# Altium schematic sheet Power Conditioning.SchDoc
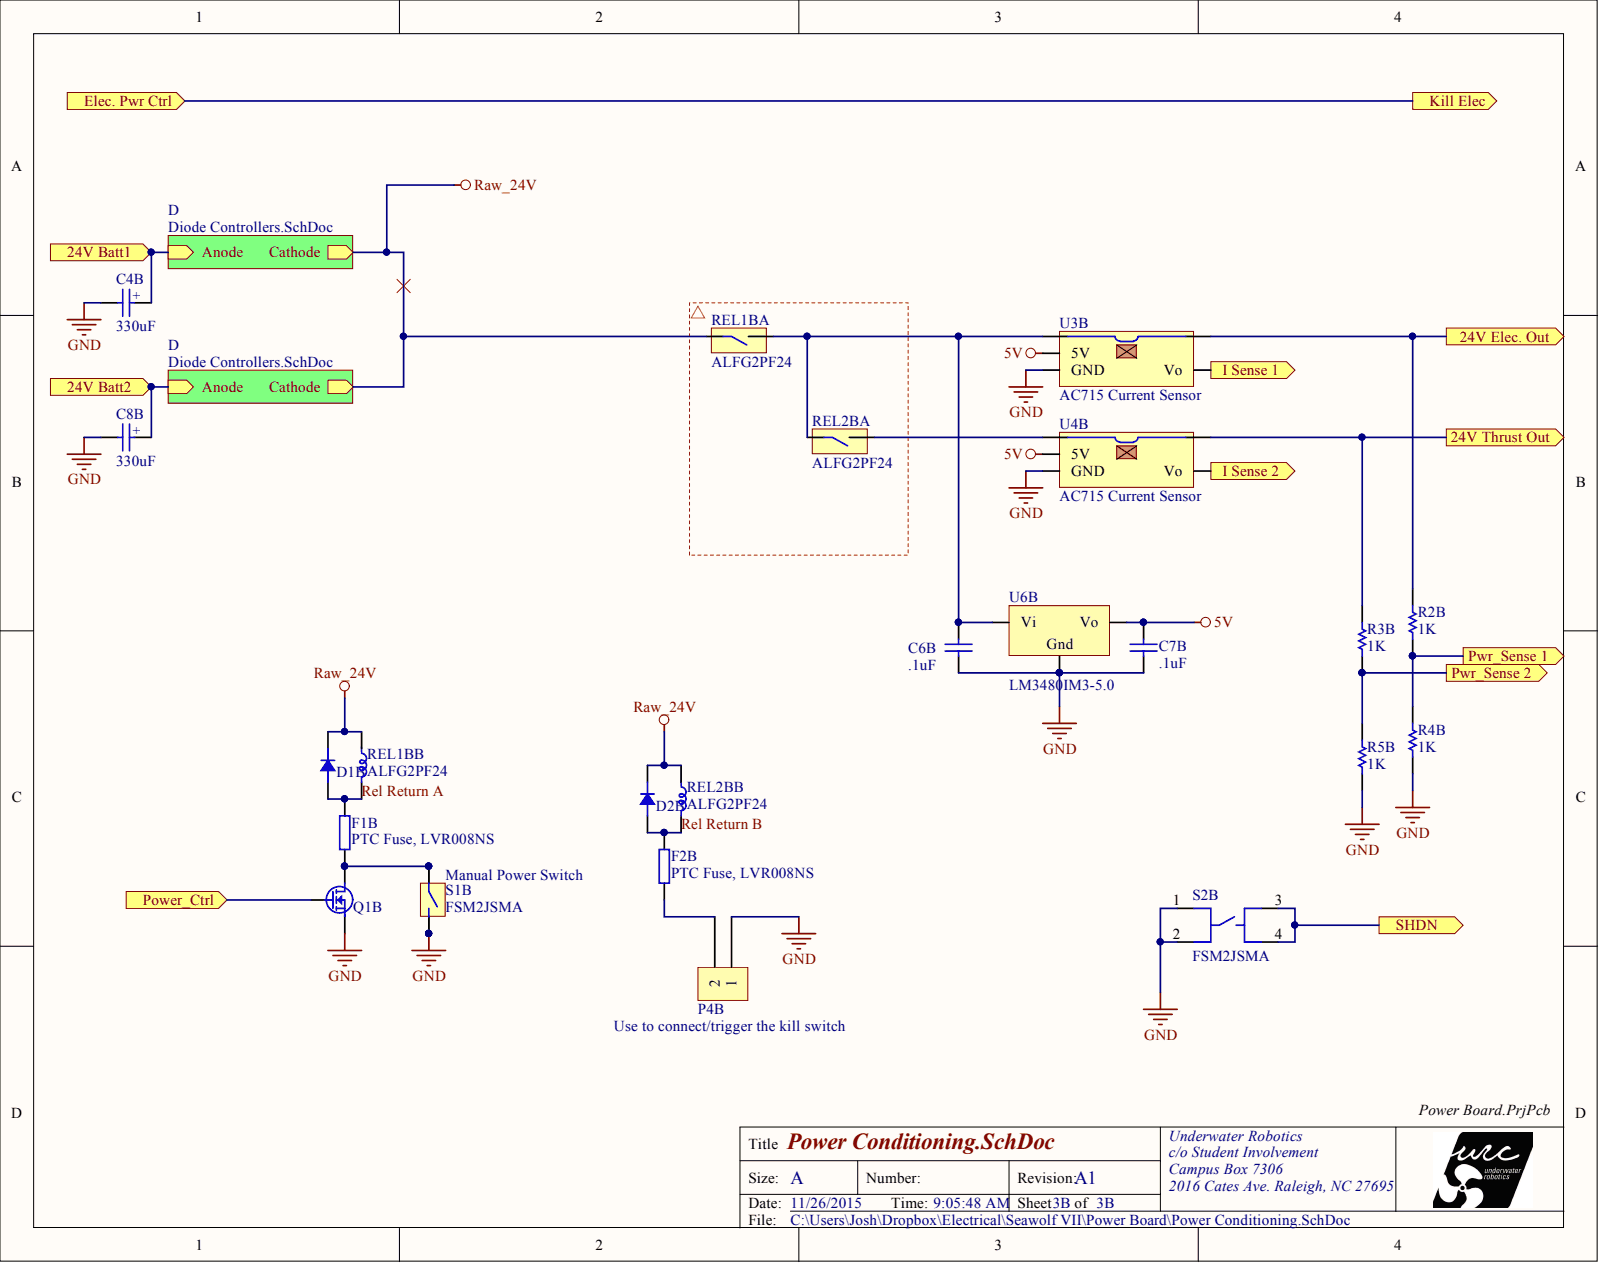
[diagram p0: Power Conditioning.SchDoc]

## Parts
C4B  value 330uF  [RADIAL-5MM]  (hidden comment: CapPol)
C6B  value .1uF  [C0402]  (hidden comment: Cap)
C7B  value .1uF  [C0402]  (hidden comment: Cap)
C8B  value 330uF  [RADIAL-5MM]  (hidden comment: CapPol)
D1B  Diode  [DO-35-0.3]  (value not drawn)
D2B  Diode  [DO-35-0.3]  (value not drawn)
F1B  PTC Fuse, LVR008NS  [LVR008NS - Resettable Fuse]
F2B  PTC Fuse, LVR008NS  [LVR008NS - Resettable Fuse]
P4B  Header  [1x2 Header]  (value not drawn)
Q1B  N-MOSFET  [2N7002-SOT23]  (value not drawn)
R2B  value 1K  [R0603]  (hidden comment: Resistor)
R3B  value 1K  [R0603]  (hidden comment: Resistor)
R4B  value 1K  [R0603]  (hidden comment: Resistor)
R5B  value 1K  [R0603]  (hidden comment: Resistor)
REL1B  ALFG2PF24  [LF-G Series Relay]  (parts A,B)
REL2B  ALFG2PF24  [LF-G Series Relay]  (parts A,B)
S1B  FSM2JSMA  [FSM2JSMA]
S2B  FSM2JSMA  [FSM2JSMA]
U3B  AC715 Current Sensor  [AC714 Current Sensor]
U4B  AC715 Current Sensor  [AC714 Current Sensor]
U6B  LM3480  Manufacturer Part Number: LM3480IM3-5.0  [LM4040-SOT23-3]

## Ports
24V BATT1, 24V BATT2, 24V ELEC. OUT, 24V THRUST OUT, ELEC. PWR CTRL, I SENSE 1, I SENSE 2, KILL ELEC, POWER_CTRL, PWR_SENSE 1, PWR_SENSE 2, SHDN

## Nets
5V: C7B.1 U3B.5(5V) U4B.5(5V) U6B.2
GND: C4B.2 C6B.2 C7B.2 C8B.2 P4B.1 Q1B.S R4B.1 R5B.1 S1B.3 S1B.4 S2B.1 S2B.2 U3B.3(GND) U4B.3(GND) U6B.1
RAW_24V: D1B.2 D2B.2 REL1B.2 REL1B.4 REL2B.2
REL RETURN A: D1B.1 F1B.1 REL1B.1
REL RETURN B: D2B.1 F2B.1 REL2B.1
SHDN: S2B.3 S2B.4 ; port SHDN
NetC4B_1: C4B.1 ; port 24V BATT1
NetC6B_1: C6B.1 REL1B.3 REL2B.4 U3B.1 U6B.3
NetC8B_1: C8B.1 ; port 24V BATT2
NetF1B_2: F1B.2 Q1B.D S1B.1 S1B.2
NetF2B_2: F2B.2 P4B.2
NetQ1B_G: Q1B.G ; port POWER_CTRL
NetR2B_1: R2B.1 R4B.2 ; port PWR_SENSE 1
NetR2B_2: R2B.2 U3B.2 ; port 24V ELEC. OUT
NetR3B_1: R3B.1 R5B.2 ; port PWR_SENSE 2
NetR3B_2: R3B.2 U4B.2 ; port 24V THRUST OUT
NetREL2B_3: REL2B.3 U4B.1
NetU3B_4: U3B.4(Vo) ; port I SENSE 1
NetU4B_4: U4B.4(Vo) ; port I SENSE 2
N00003: ; port ELEC. PWR CTRL, port KILL ELEC

## Library data of the parts
C4B C8B = CapPol: Polarized Capacitor; Component Kind: Standard; Library Name: URC.SchLib
C6B C7B = Cap: Unpolarized Capacitor; Manufacturer: Taiyo Yuden; Manufacturer Part Number: LMK105BJ104KV-F; Capacitance: 0.1µF; Temperature Coefficient: X5R; Tolerance: ±10%; Voltage - Rated: 10V; Applications: General Purpose; Category: Capacitors; Component Kind: Standard; ComponentLink1Description: http://www.yuden.co.jp/or/product/category/capacitor/LMK105BJ104KV-F.pdf; ComponentLink1URL: http://www.yuden.co.jp/or/product/category/capacitor/LMK105BJ104KV-F.pdf; Features: -; Height - Seated (Max): -; Lead Spacing: -; Lead Style: -; Mounting Type: Surface Mount, MLCC; Operating Temperature: -55°C ~ 85°C; Package / Case: 0402 (1005 Metric); Packaging: Tape & Reel (TR); Pricing 1: 10000=0.0021, 20000=0.002, 30000=0.0019, 50000=0.0017 (USD); Ratings: -; RoHS: RoHS Compliant; Size / Dimension: 0.039" L x 0.020" W (1.00mm x 0.50mm); Stock 1: 17630000; Supplier 1: Digi-Key; Supplier Part Number 1: 587-1227-2-ND; Thickness (Max): 0.022" (0.55mm); Library Name: URC_Lib.IntLib
D1B D2B = Diode: General Purpose Diode; Component Kind: Standard; Library Name: URC.SchLib
F1B F2B = PTC Fuse, LVR008NS: Generic Fuse; Component Kind: Standard; Supplier 1: Newark; Supplier Part Number 1: 45P7788; Library Name: URC.SchLib
P4B = 1x2 Header: Component Kind: Standard; Description: 1x2 Header; Library Name: URC_Lib.IntLib
Q1B = N-MOSFET: N-Channel MOSFET; Component Kind: Standard; Supplier 1: Digi-Key; Supplier Part Number 1: 568-5818-1-ND; Library Name: URC.IntLib
R2B R3B R4B R5B = Res: Tolerance: 5%; Component Kind: Standard; Description: Resistor; Library Name: URC.SchLib
REL1B REL2B = ALFG2PF24: 24V, 33A General Purpose Relay; Component Kind: Standard; Library Name: Panasonic SchLib.SchLib
S1B S2B = FSM2JSMA: Tactile Switch (OFF-MOM); Component Kind: Standard; URL: http://search.digikey.com/us/en/products/FSM2JSMA/450-1130-ND/525819; Library Name: URC.SchLib
U6B = LM3480: 5V, 0.1A Fixed LDO; Manufacturer 1: TEXAS INSTRUMENTS; Description 1: TEXAS INSTRUMENTS - LM3480IM3-5.0 - LDO VOLTAGE REGULATOR, 5V, 0.1A, 3-SOT-23; Dropout Voltage Vdo: 900; Input Voltage Max: 30; Input Voltage Min: 0; LDO Regulator Case Style: SOT-23; No. of Pins: 3; Output Current: 100; Component Kind: Standard; ComponentLink1Description: http://www.farnell.com/cad/1562346.zip; ComponentLink1URL: http://www.farnell.com/cad/1562346.zip; ComponentLink2Description: http://www.farnell.com/datasheets/1853525.pdf; ComponentLink2URL: http://www.farnell.com/datasheets/1853525.pdf; Operating Temperature Min: -40 C; Pricing 1: 1=1.24, 10=0.986, 100=0.757, 1000=0.529 (USD); RoHS: NO; Stock 1: 132; Supplier 1: Newark; Supplier Part Number 1: 83F3816; Library Name: URC.SchLib
U3B U4B = AC715 Current Sensor: Component Kind: Standard; URL: https://www.pololu.com/product/1186/resources; Library Name: URC.SchLib
